# Altium schematic sheet Relay_Node.SchDoc
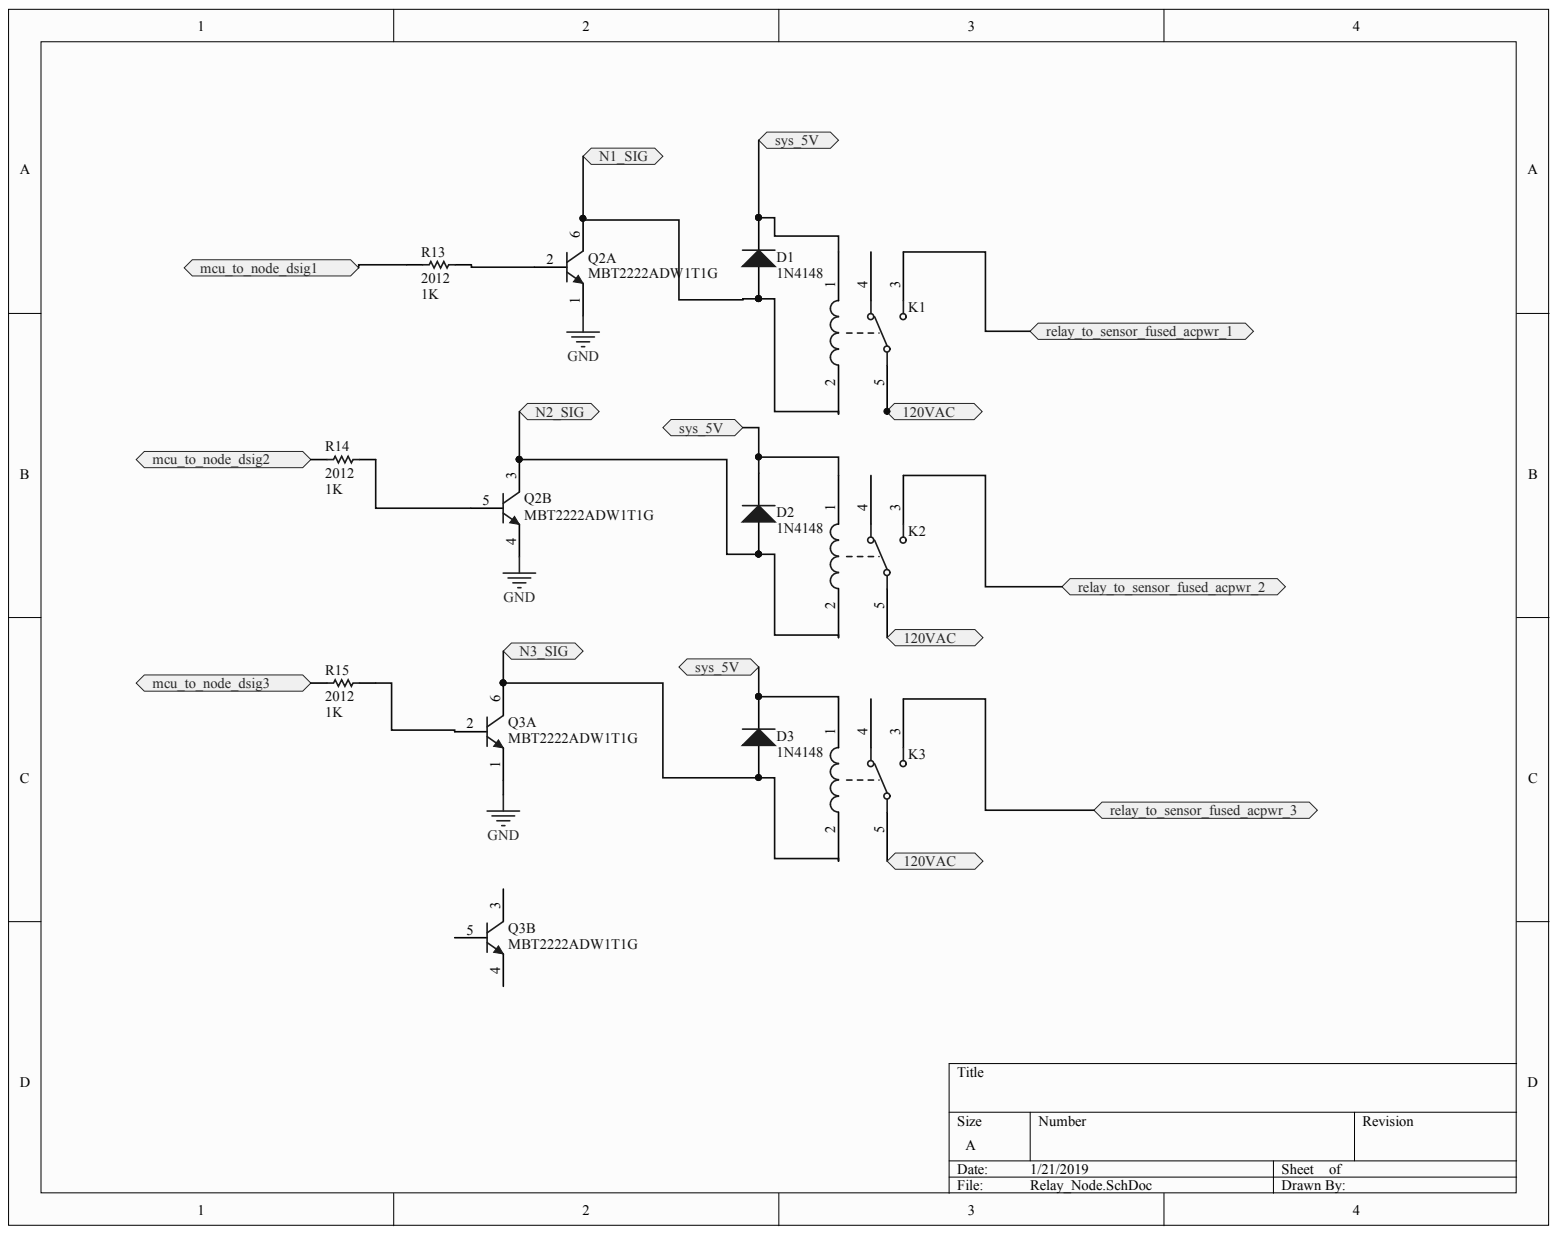
[diagram p0: Relay_Node.SchDoc]

## Parts
D1  1N4148  [PCB-1N4148W-7-F]
D2  1N4148  [PCB-1N4148W-7-F]
D3  1N4148  [PCB-1N4148W-7-F]
K1  (no value)  [PCB-ibl107dezdlhh8vogeem-1]
K2  (no value)  [PCB-ibl107dezdlhh8vogeem-1]
K3  (no value)  [PCB-ibl107dezdlhh8vogeem-1]
Q2  MBT2222ADW1T1G  [ONSC-SOT-363-6-419B-02_V]  (parts A,B)
Q3  MBT2222ADW1T1G  [ONSC-SOT-363-6-419B-02_V]  (parts A,B)
R13  Case/Package (SI): 2012  Resistance: 1K  [RESC2013X70X40ML20T20]  (hidden comment: Panasonic ERJ-6GEYJ822V)
R14  Case/Package (SI): 2012  Resistance: 1K  [RESC2013X70X40ML20T20]  (hidden comment: Panasonic ERJ-6GEYJ822V)
R15  Case/Package (SI): 2012  Resistance: 1K  [RESC2013X70X40ML20T20]  (hidden comment: Panasonic ERJ-6GEYJ822V)

## Ports
120VAC, MCU_TO_NODE_DSIG1, MCU_TO_NODE_DSIG2, MCU_TO_NODE_DSIG3, N1_SIG, N2_SIG, N3_SIG, RELAY_TO_SENSOR_FUSED_ACPWR_1, RELAY_TO_SENSOR_FUSED_ACPWR_2, RELAY_TO_SENSOR_FUSED_ACPWR_3, SYS_5V

## Nets
GND: Q2.1 Q2.4 Q3.1
MCU_TO_NODE_DSIG1: R13.2 ; port MCU_TO_NODE_DSIG1
MCU_TO_NODE_DSIG2: R14.2 ; port MCU_TO_NODE_DSIG2
MCU_TO_NODE_DSIG3: R15.2 ; port MCU_TO_NODE_DSIG3
RELAY_TO_SENSOR_FUSED_ACPWR_1: K1.3 ; port RELAY_TO_SENSOR_FUSED_ACPWR_1
RELAY_TO_SENSOR_FUSED_ACPWR_2: K2.3 ; port RELAY_TO_SENSOR_FUSED_ACPWR_2
RELAY_TO_SENSOR_FUSED_ACPWR_3: K3.3 ; port RELAY_TO_SENSOR_FUSED_ACPWR_3
SYS_5V: D1.2 D2.2 D3.2 K1.1 K2.1 K3.1 ; port SYS_5V
120VAC: K1.5 K2.5 K3.5 ; port 120VAC
N1_SIG: D1.1 K1.2 Q2.6 ; port N1_SIG
N2_SIG: D2.1 K2.2 Q2.3 ; port N2_SIG
N3_SIG: D3.1 K3.2 Q3.6 ; port N3_SIG
NetQ2_2: Q2.2 R13.1
NetQ2_5: Q2.5 R14.1
NetQ3_2: Q3.2 R15.1

Not connected: K1 4; K2 4; K3 4; Q3 3 4 5

## Library data of the parts
D1-D3 = CMP-791fb3587d52a6d8-18: Fast Switching Diode, 100V, 400mW, Surface Mount, SOD-123; Manufacturer Part Number: 1N4148W-7-F; Current Rating: 150 mA; Output Current: 300mA (max); Case/Package: SOD-123; Component Kind: Standard; Datasheet PDF: https://www.diodes.com/assets/Datasheets/ds30086.pdf; Library Name: CommunityVault; Manufacturer PN: 1N4148W-7-F; Mounting Style: Surface Mount; OctopartID: 791fb3587d52a6d8; Octopart URL: https://octopart.com/1n4148w-7-f-diodes+inc.-55386411; RoHS: Compliant; Voltage Rating (DC): 100V
K1-K3 = CMP-a1ab6ad798d87e6c-2: Electromechanical Relay 5VDC 15A SPDT (( 19.2mm 15.5mm 15mm)) THT General Purpose Relay; Manufacturer: TE Connectivity; Manufacturer Part Number: 1-1721150-0; Coil Power: 360mW; Coil Resistance: 70Ω; Coil Voltage: 5V; ELV Compliant: Compliant; Lead-Free Status: Lead Free; Mechanical Life: 1000000 operations; Operate/Release Time: 10ms / 5ms; Operation Frequency: 600/hours; Switching Current: 10A; Switching load (min): 100mA @ 5VDC; Switching Voltage: 277VAC / 28VDC; Component Kind: Standard; Library Name: CommunityVault; Lifecycle Status: Active; Mounting Style: PCB; OctopartID: a1ab6ad798d87e6c; Octopart URL: http://octopart.com/1-1721150-0-te+connectivity-41294869; Packaging: Tube; RoHS: Compliant; Size - Height: 15.8mm; Size - Length: 19mm; Size - Width: 15.5mm; Switch Type: SPDT
Q2 Q3 = CMP-854660-2: General Purpose Transistor, NPN Silicon, 6-Pin SOT-363, Pb-Free, Tape and Reel; Current Rating: 0.6 A; Lead-Free Status: Lead Free; Voltage Rating (DC): 40.0 V; Case/Package: SOT-363; Component Kind: Standard; Halogen Free Status: Halogen Free; Library Name: CommunityVault; Lifecycle Status: Active; Manufacturer Part Number: MBT2222ADW1T1G; Mounting Style: Surface Mount; Number of Pins: 6; OctopartID: 1f01d6078701c0c6; Octopart Url: https://octopart.com/mbt2222adw1t1g-on+semiconductor-834918; Packaging: Tape; REACH SVHC Compliance: No SVHC; RoHS: Compliant
R13-R15 = CMP-3687578-2: Thick Film Resistors - SMD 0805 8.2Kohms 5% Tol; Lead-Free Status: Lead Free; Power Rating: 0.125 W; Voltage Rating (DC): 150.0 V; Case/Package: 0805; Component Kind: Standard; Library Name: CommunityVault; Lifecycle Status: Not Listed by Manufacturer; Manufacturer Part Number: ERJ-6GEYJ822V; Mounting Style: Surface Mount; OctopartID: 0556cf11906ada91; Octopart Url: https://octopart.com/erj-6geyj822v-panasonic-55423066; Packaging: Cut Tape (CT); Resistance Tolerance: ±5%; RoHS: Compliant; Size-Height: 0.0006 m; Size-Length: 0.002 m; Size-Width: 0.00125 m
